# Altium schematic sheet MCU.SchDoc
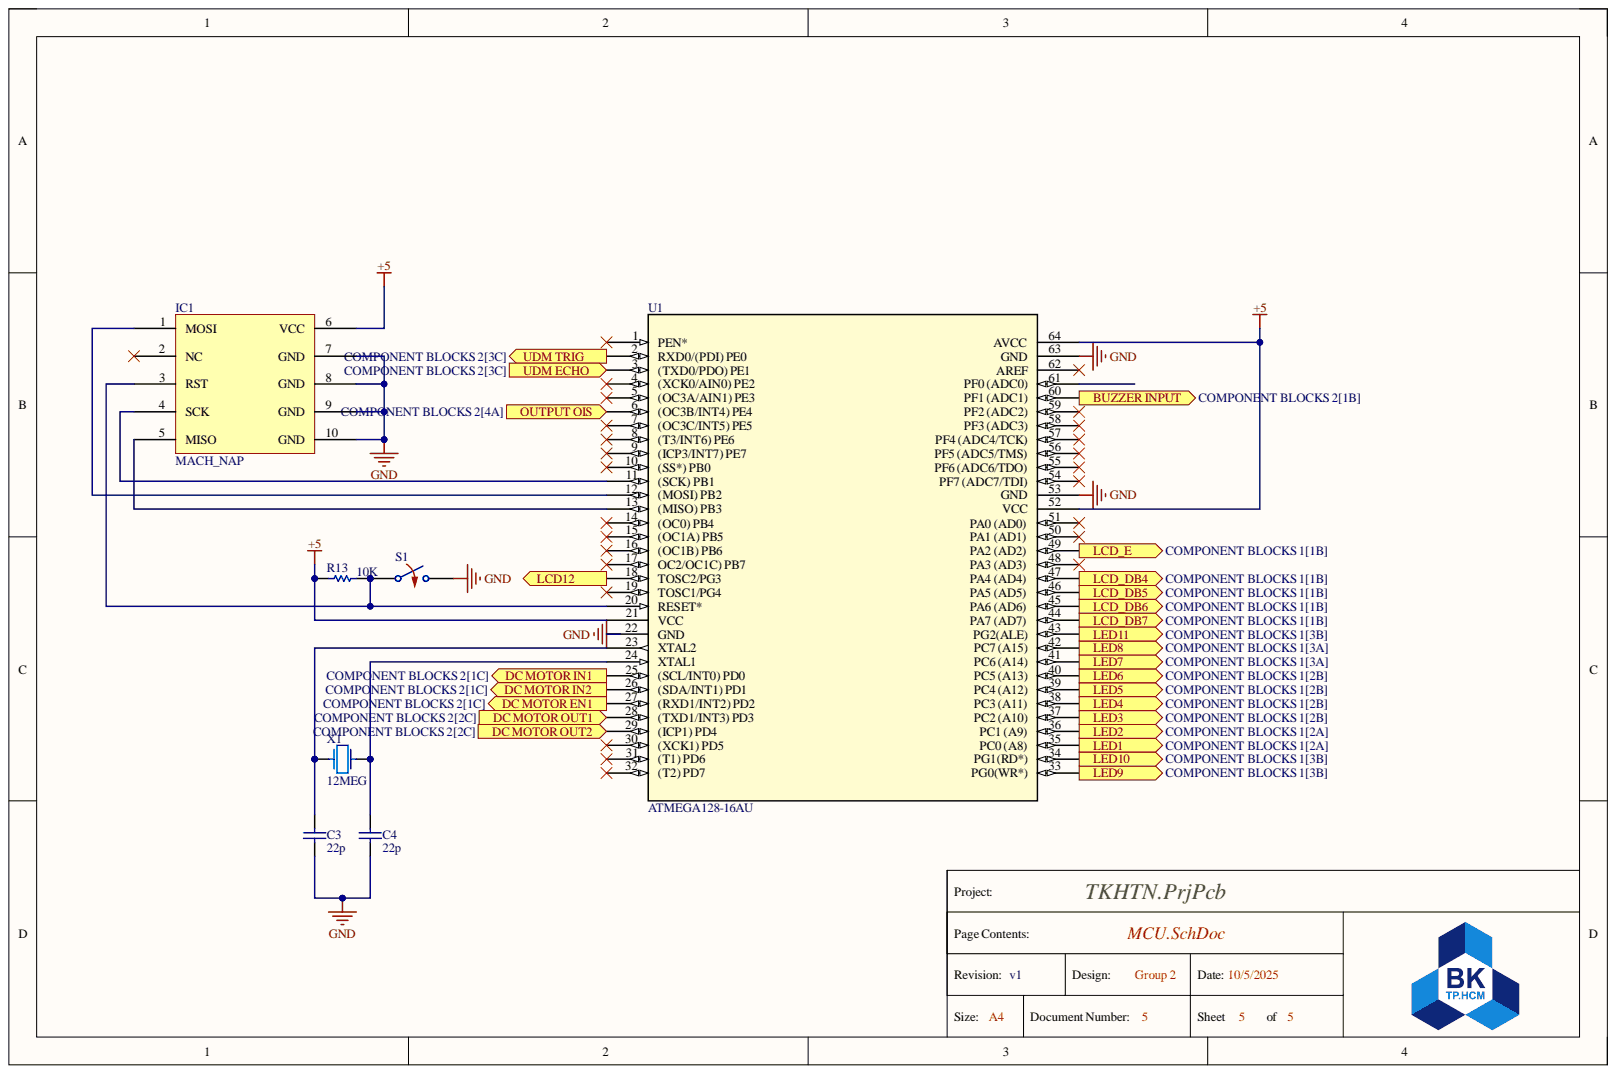
[diagram p0: MCU.SchDoc]

## Parts
C3  value 22p  (hidden comment: Capacitor)
C4  value 22p  (hidden comment: Capacitor)
IC1  MACH_NAP
R13  value 10K  (hidden comment: Resistor)
S1  Switch Normally Opened  (value not drawn)
U1  ATMEGA128-16AU  [64A_ATM]
X1  F0: 12MEG  (hidden comment: Crystal)

## Ports
BUZZER INPUT, DC MOTOR EN1, DC MOTOR IN1, DC MOTOR IN2, DC MOTOR OUT1, DC MOTOR OUT2, LCD12, LCD_DB4, LCD_DB5, LCD_DB6, LCD_DB7, LCD_E, LED1, LED2, LED3, LED4, LED5, LED6, LED7, LED8, LED9, LED10, LED11, OUTPUT OIS, UDM ECHO, UDM TRIG

## Nets
+5: IC1.6(VCC) R13.1 U1.21(VCC) U1.52(VCC) U1.64(AVCC)
GND: C3.1 C4.1 IC1.7(GND) IC1.8(GND) IC1.9(GND) IC1.10(GND) S1.2 U1.22(GND) U1.53(GND) U1.63(GND)
BUZZER INPUT: U1.60(PF1_(ADC1)) ; port BUZZER INPUT
DC MOTOR EN1: U1.27((RXD1/INT2)_PD2) ; port DC MOTOR EN1
DC MOTOR IN1: U1.25((SCL/INT0)_PD0) ; port DC MOTOR IN1
DC MOTOR IN2: U1.26((SDA/INT1)_PD1) ; port DC MOTOR IN2
DC MOTOR OUT1: U1.28((TXD1/INT3)_PD3) ; port DC MOTOR OUT1
DC MOTOR OUT2: U1.29((ICP1)_PD4) ; port DC MOTOR OUT2
LCD12: U1.18(TOSC2/PG3) ; port LCD12
LCD_DB4: U1.47(PA4_(AD4)) ; port LCD_DB4
LCD_DB5: U1.46(PA5_(AD5)) ; port LCD_DB5
LCD_DB6: U1.45(PA6_(AD6)) ; port LCD_DB6
LCD_DB7: U1.44(PA7_(AD7)) ; port LCD_DB7
LCD_E: U1.49(PA2_(AD2)) ; port LCD_E
LED1: U1.35(PC0_(A8)) ; port LED1
LED2: U1.36(PC1_(A9)) ; port LED2
LED3: U1.37(PC2_(A10)) ; port LED3
LED4: U1.38(PC3_(A11)) ; port LED4
LED5: U1.39(PC4_(A12)) ; port LED5
LED6: U1.40(PC5_(A13)) ; port LED6
LED7: U1.41(PC6_(A14)) ; port LED7
LED8: U1.42(PC7_(A15)) ; port LED8
LED9: U1.33(PG0(WR*)) ; port LED9
LED10: U1.34(PG1(RD*)) ; port LED10
LED11: U1.43(PG2(ALE)) ; port LED11
OUTPUT OIS: U1.6((OC3B/INT4)_PE4) ; port OUTPUT OIS
UDM ECHO: U1.3((TXD0/PDO)_PE1) ; port UDM ECHO
UDM TRIG: U1.2(RXD0/(PDI)_PE0) ; port UDM TRIG
NetC3_2: C3.2 U1.23(XTAL2) X1.1
NetC4_2: C4.2 U1.24(XTAL1) X1.2
NetIC1_1: IC1.1(MOSI) U1.12((MOSI)_PB2)
NetIC1_3: IC1.3(RST) R13.2 S1.1 U1.20(RESET*)
NetIC1_4: IC1.4(SCK) U1.11((SCK)_PB1)
NetIC1_5: IC1.5(MISO) U1.13((MISO)_PB3)

Not connected: IC1 2(NC); U1 1(PEN*) 4((XCK0/AIN0)_PE2) 5((OC3A/AIN1)_PE3) 7((OC3C/INT5)_PE5) 8((T3/INT6)_PE6) 9((ICP3/INT7)_PE7) 10((SS*)_PB0) 14((OC0)_PB4) 15((OC1A)_PB5) 16((OC1B)_PB6) 17(OC2/OC1C)_PB7) 19(TOSC1/PG4) 30((XCK1)_PD5) 31((T1)_PD6) 32((T2)_PD7) 48(PA3_(AD3)) 50(PA1_(AD1)) 51(PA0_(AD0)) 54(PF7_(ADC7/TDI)) 55(PF6_(ADC6/TDO)) 56(PF5_(ADC5/TMS)) 57(PF4_(ADC4/TCK)) 58(PF3_(ADC3)) 59(PF2_(ADC2)) 61(PF0_(ADC0)) 62(AREF)

## Library data of the parts
C3 C4 = Capacitor: SimulationGenericComponent: True; Component Kind: Standard; Description: Capacitor; Library Name: Simulation Generic Components.IntLib; Simulation: C_Capacitor_Default
R13 = Resistor: SimulationGenericComponent: True; TC1: 0; Component Kind: Standard; Description: Resistor; Library Name: Simulation Generic Components.IntLib; Simulation: R_Resistor_Default; TC2: 0
S1 = SW NO: closed: 0; Rclosed: 1m; Ropen: 1Meg; SimulationGenericComponent: True; Component Kind: Standard; Description: Switch Normally Opened; Library Name: Simulation Generic Components.IntLib; Simulation: SPST_SWITCH
U1 = ATMEGA128-16AU: No Description Available; Manufacturer_Name: Microchip; Component Kind: Standard; Library Name: ATMEGA128-16AU.IntLib; Manufacturer_Part_Number: ATMEGA128-16AU; RefDes: RefDes; Type: Type; Copyright: Copyright (C) 2025 Ultra Librarian. All rights reserved.
X1 = Crystal: Q: 50K; R: 500; SimulationGenericComponent: True; Component Kind: Standard; Description: Crystal; Library Name: Simulation Generic Components.IntLib; Simulation: CRYSTAL
IC1 = MACH_NAP: Component Kind: Standard; Library Name: MACH_NAP.IntLib
